# Altium schematic sheet NAS_XEM7310_Top.SchDoc
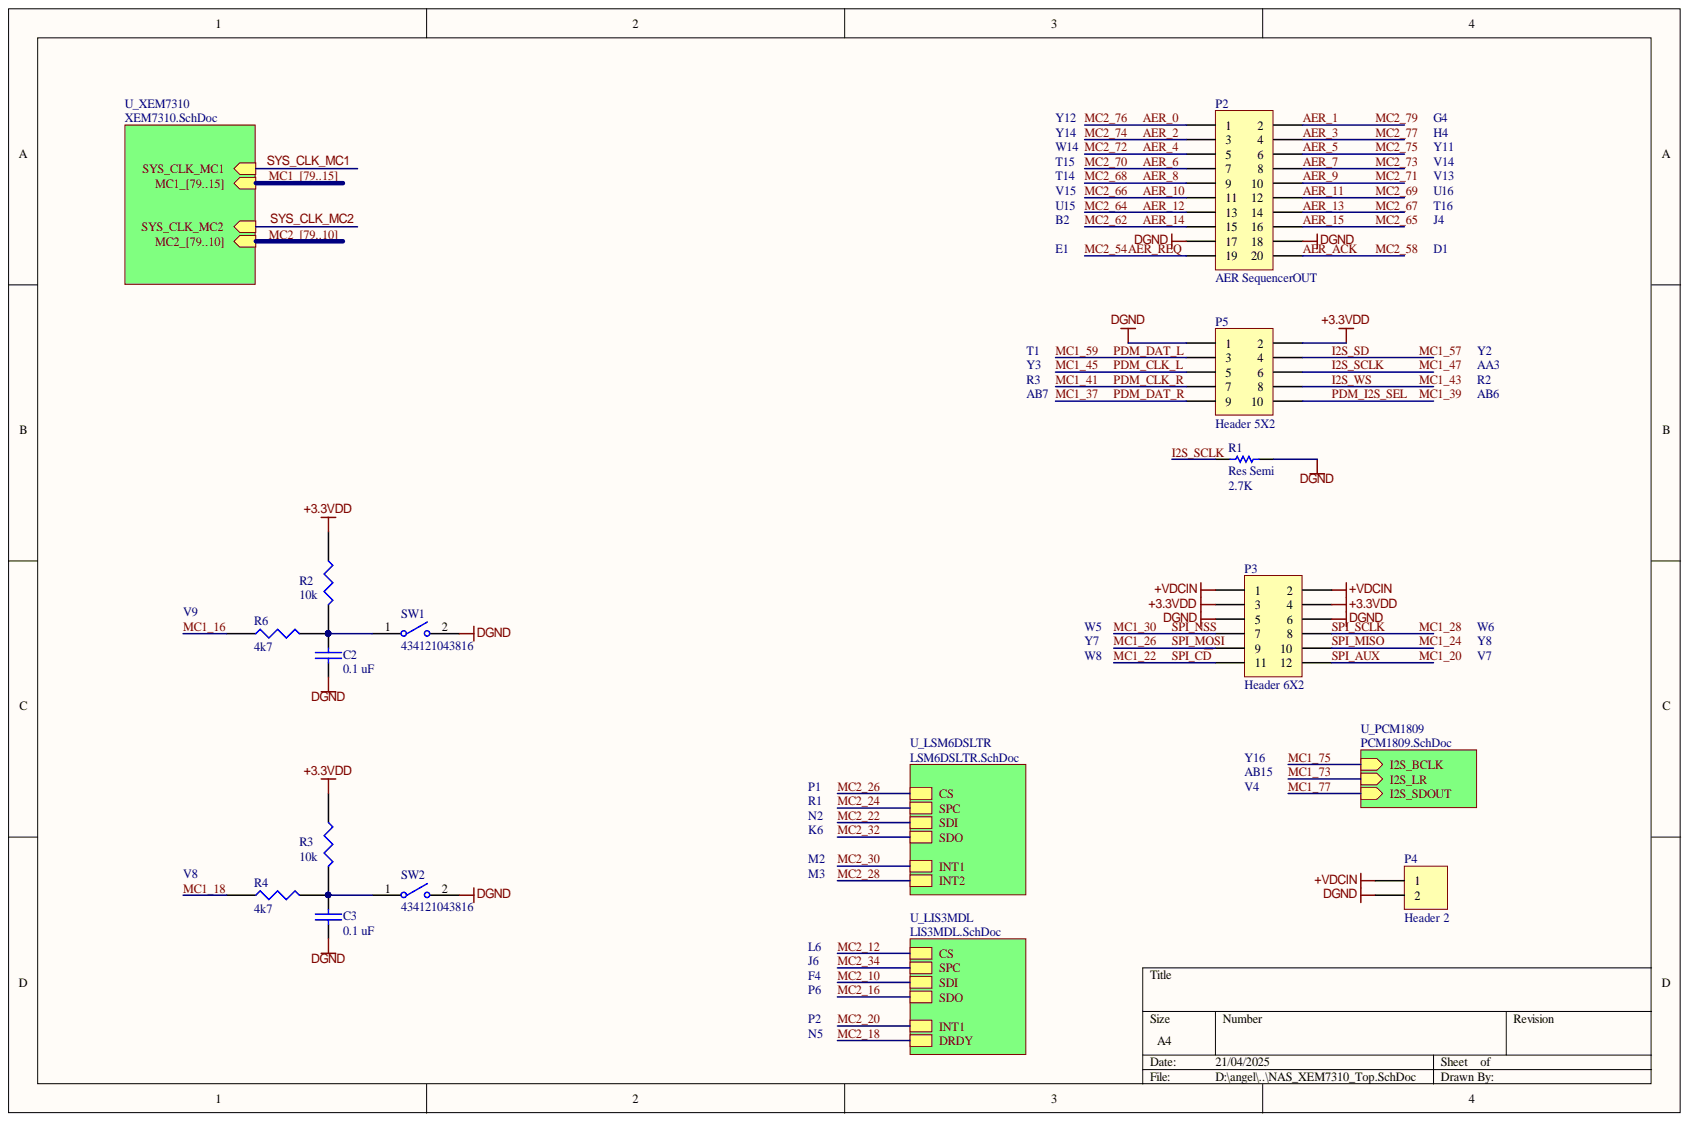
[diagram p0: NAS_XEM7310_Top.SchDoc]

## Parts
C2  0.1 uF  [1608[0603]]
C3  0.1 uF  [1608[0603]]
P2  AER SequencerOUT  [HDR2X10_HOUSING]
P3  Header 6X2  [HDR2X6]
P4  Header 2  [HDR1X2]
P5  Header 5X2  [HDR2X5]
R1  Res Semi  value 2.7K  [0603]
R2  10k  [1608[0603]]
R3  10k  [1608[0603]]
R4  4k7  [1608[0603]]
R6  4k7  [1608[0603]]
SW1  434121043816  [434121043816]
SW2  434121043816  [434121043816]

## Nets
+3.3VDD: P3.3 P3.4 P5.2 R2.1 R3.1
+VDCIN: P3.1 P3.2 P4.1
DGND: C2.2 C3.2 P2.17 P2.18 P3.5 P3.6 P4.2 P5.1 R1.2 SW1.2 SW2.2
MC1_16: R6.1  [also labelled MC1_]
MC1_18: R4.1  [also labelled MC1_]
MC1_20: P3.12  [also labelled MC1_]
MC1_22: P3.11  [also labelled MC1_]
MC1_24: P3.10  [also labelled MC1_]
MC1_26: P3.9  [also labelled MC1_]
MC1_28: P3.8  [also labelled MC1_]
MC1_30: P3.7  [also labelled MC1_]
MC1_37: P5.9  [also labelled MC1_]
MC1_39: P5.10  [also labelled MC1_]
MC1_41: P5.7  [also labelled MC1_]
MC1_45: P5.5  [also labelled MC1_]
MC1_59: P5.3  [also labelled MC1_]
NetC2_1: C2.1 R2.2 R6.2 SW1.1
NetC3_1: C3.1 R3.2 R4.2 SW2.1
MC1_15:  [also labelled MC1_]
MC1_17:  [also labelled MC1_]
MC1_19:  [also labelled MC1_]
MC1_21:  [also labelled MC1_]
MC1_23:  [also labelled MC1_]
MC1_25:  [also labelled MC1_]
MC1_27:  [also labelled MC1_]
MC1_29:  [also labelled MC1_]
MC1_31:  [also labelled MC1_]
MC1_32:  [also labelled MC1_]
MC1_33:  [also labelled MC1_]
MC1_34:  [also labelled MC1_]
MC1_35:  [also labelled MC1_]
MC1_36:  [also labelled MC1_]
MC1_38:  [also labelled MC1_]
MC1_40:  [also labelled MC1_]
MC1_42:  [also labelled MC1_]
MC1_44:  [also labelled MC1_]
MC1_46:  [also labelled MC1_]
MC1_48:  [also labelled MC1_]
MC1_49:  [also labelled MC1_]
MC1_50:  [also labelled MC1_]
MC1_51:  [also labelled MC1_]
MC1_52:  [also labelled MC1_]
MC1_53:  [also labelled MC1_]
MC1_54:  [also labelled MC1_]
MC1_55:  [also labelled MC1_]
MC1_56:  [also labelled MC1_]
MC1_58:  [also labelled MC1_]
MC1_60:  [also labelled MC1_]
MC1_61:  [also labelled MC1_]
MC1_62:  [also labelled MC1_]
MC1_63:  [also labelled MC1_]
MC1_64:  [also labelled MC1_]
MC1_65:  [also labelled MC1_]
MC1_66:  [also labelled MC1_]
MC1_67:  [also labelled MC1_]
MC1_68:  [also labelled MC1_]
MC1_69:  [also labelled MC1_]
MC1_70:  [also labelled MC1_]
MC1_71:  [also labelled MC1_]
MC1_72:  [also labelled MC1_]
MC1_73:  [also labelled MC1_]
MC1_74:  [also labelled MC1_]
MC1_75:  [also labelled MC1_]
MC1_76:  [also labelled MC1_]
MC1_77:  [also labelled MC1_]
MC1_78:  [also labelled MC1_]
MC1_79:  [also labelled MC1_]
MC2_10:  [also labelled MC2_]
MC2_11:  [also labelled MC2_]
MC2_12:  [also labelled MC2_]
MC2_13:  [also labelled MC2_]
MC2_14:  [also labelled MC2_]
MC2_15:  [also labelled MC2_]
MC2_16:  [also labelled MC2_]
MC2_17:  [also labelled MC2_]
MC2_18:  [also labelled MC2_]
MC2_19:  [also labelled MC2_]
MC2_20:  [also labelled MC2_]
MC2_21:  [also labelled MC2_]
MC2_22:  [also labelled MC2_]
MC2_23:  [also labelled MC2_]
MC2_24:  [also labelled MC2_]
MC2_25:  [also labelled MC2_]
MC2_26:  [also labelled MC2_]
MC2_27:  [also labelled MC2_]
MC2_28:  [also labelled MC2_]
MC2_29:  [also labelled MC2_]
MC2_30:  [also labelled MC2_]
MC2_31:  [also labelled MC2_]
MC2_32:  [also labelled MC2_]
MC2_33:  [also labelled MC2_]
MC2_34:  [also labelled MC2_]
MC2_35:  [also labelled MC2_]
MC2_36:  [also labelled MC2_]
MC2_37:  [also labelled MC2_]
MC2_38:  [also labelled MC2_]
MC2_39:  [also labelled MC2_]
MC2_40:  [also labelled MC2_]
MC2_41:  [also labelled MC2_]
MC2_42:  [also labelled MC2_]
MC2_43:  [also labelled MC2_]
MC2_44:  [also labelled MC2_]
MC2_45:  [also labelled MC2_]
MC2_46:  [also labelled MC2_]
MC2_47:  [also labelled MC2_]
MC2_48:  [also labelled MC2_]
MC2_49:  [also labelled MC2_]
MC2_50:  [also labelled MC2_]
MC2_51:  [also labelled MC2_]
MC2_52:  [also labelled MC2_]
MC2_53:  [also labelled MC2_]
MC2_55:  [also labelled MC2_]
MC2_56:  [also labelled MC2_]
MC2_57:  [also labelled MC2_]
MC2_59:  [also labelled MC2_]
MC2_60:  [also labelled MC2_]
MC2_61:  [also labelled MC2_]
MC2_63:  [also labelled MC2_]
MC2_78:  [also labelled MC2_]
SYS_CLK_MC1:
SYS_CLK_MC2:

Not connected: P2 1 2 3 4 5 6 7 8 9 10 11 12 13 14 15 16 19 20; P5 4 6 8; R1 1

## Library data of the parts
C2 C3 = CAP: Capacitor; Component Kind: Standard
P2 = Header 10X2: Header, 10-Pin, Dual row; Referencia: T821120A1S100CEU; Component Kind: Standard; Library Name: Miscellaneous Connectors.IntLib; Revision: 17-Jul-2002: Re-released for DXP Platform.; Signal Integrity: Connector; Publisher: Altium Limited
P3 = Header 6X2: Header, 6-Pin, Dual row; Component Kind: Standard; LatestRevisionDate: 17-Jul-2002; LatestRevisionNote: Re-released for DXP Platform.; Library Name: Miscellaneous Connectors.IntLib; Signal Integrity: Connector; Publisher: Altium Limited
P4 = Header 2: Header, 2-Pin; Component Kind: Standard; LatestRevisionDate: 17-Jul-2002; LatestRevisionNote: Re-released for DXP Platform.; Library Name: Miscellaneous Connectors.IntLib; Signal Integrity: Connector; Publisher: Altium Limited
P5 = Header 5X2: Header, 5-Pin, Dual row; Component Kind: Standard; LatestRevisionDate: 17-Jul-2002; LatestRevisionNote: Re-released for DXP Platform.; Library Name: Miscellaneous Connectors.IntLib; Signal Integrity: Connector; Publisher: Altium Limited
R1 = Res Semi: Semiconductor Resistor; Component Kind: Standard; LatestRevisionDate: 17-Jul-2002; LatestRevisionNote: Re-released for DXP Platform.; Library Name: Miscellaneous Devices.IntLib; PackageReference: AXIAL-0.5; Published: 8-Jun-2000; Signal Integrity: Res Semi; Simulation: RES; Publisher: Altium Limited
R2-R4 R6 = RES1: =VALUE; Component Kind: Standard
SW1 SW2 = CMP-1459-00005-3: Switch Tactile Spst-no 0.05A 12V; Contact Current Rating: 50mA; Contact Resistance: 100mΩ; Operating Force: 160N; Component Kind: Standard; Contact Plating: Silver; Current Rating: 50mA; Height: 4.3mm; Insulation Resistance: 100MΩ; Library Name: Altium Content Vault; Max Operating Temperature: 85°C; Min Operating Temperature: -40°C; Packaging: Tape and Reel; RoHS: Compliant; Voltage Rating: 12V; Width: 6mm
